# Altium schematic sheet LoRa transceiver_NOswitch.SchDoc("LoRa transceiver_NOswitch")
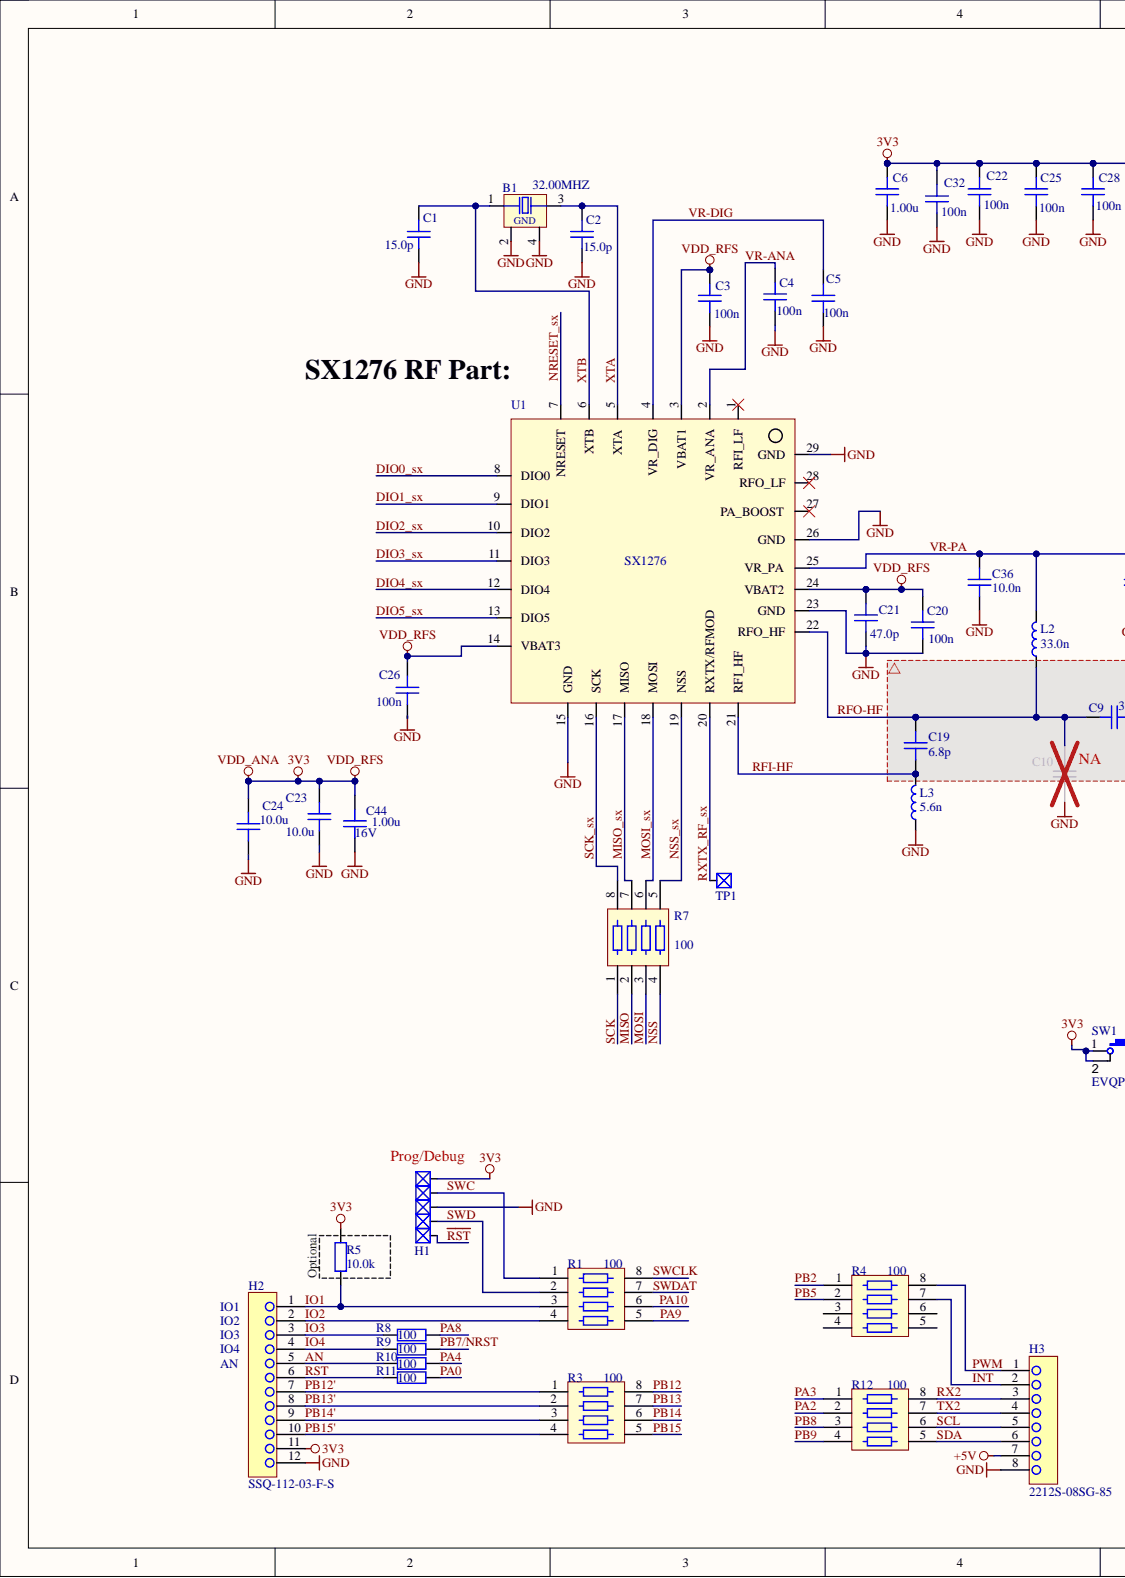
[diagram p0: LoRa transceiver_NOswitch.SchDoc, left]
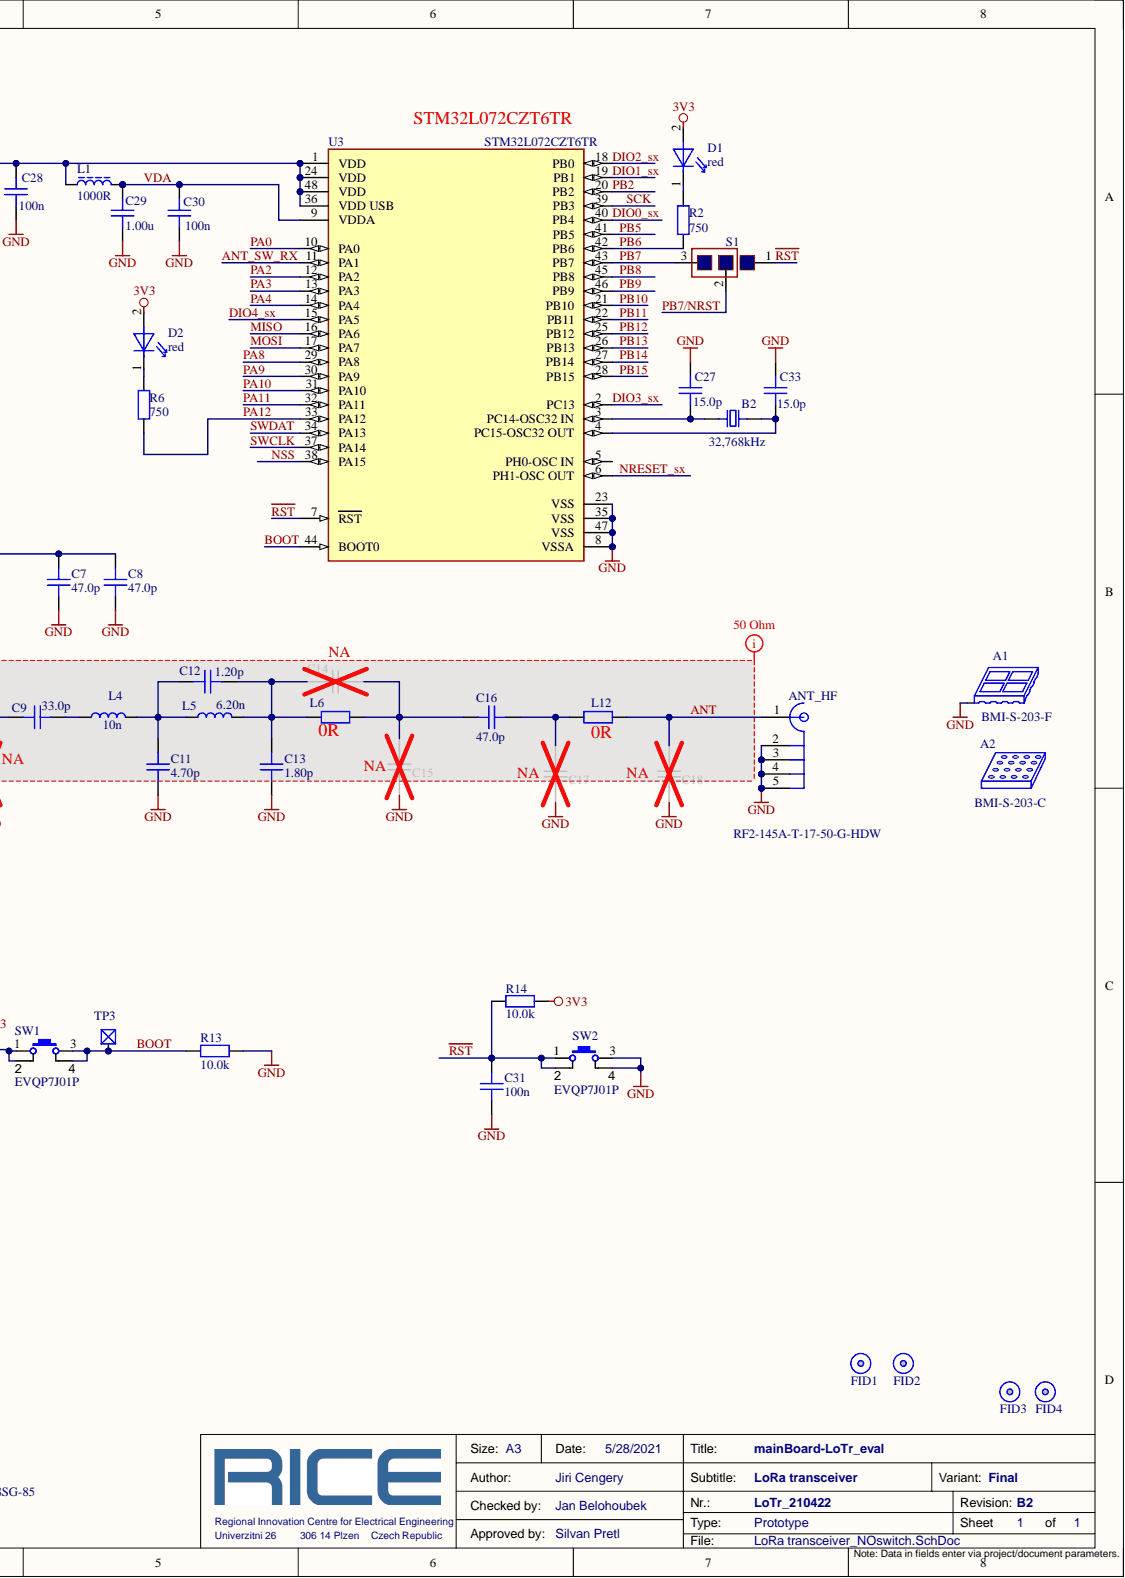
[diagram p1: LoRa transceiver_NOswitch.SchDoc, right]

## Parts
A1  BMI-S-203-F  [sm_mch_shield_W261M_L261M_H51M]
A2  BMI-S-203-C  [sm_mch_ShieldCover_W267M_L267M_H20M]
ANT_HF  RF2-145A-T-17-50-G-HDW  [SM_CON_SMA_F_EDGE_RF2145AT1750G]
B1  32.00MHZ  [sm_osc_402]
B2  32,768kHz  [sm_osc_ABS07]
C1  15.0p  [sm_cap_0402]
C2  15.0p  [sm_cap_0402]
C3  100n  [sm_cap_0402]
C4  100n  [sm_cap_0402]
C5  100n  [sm_cap_0402]
C6  1.00u  [sm_cap_0603]
C7  47.0p  [sm_cap_0402]
C8  47.0p  [sm_cap_0402]
C9  33.0p  [sm_cap_0402]
C10  1.5p  [sm_cap_0402]  (value not drawn)
C11  4.70p  [sm_cap_0402]
C12  1.20p  [sm_cap_0402]
C13  1.80p  [sm_cap_0402]
C14  4.70p  [sm_cap_0402]  (value not drawn)
C15  1.80p  [sm_cap_0402]  (value not drawn)
C16  47.0p  [sm_cap_0402]
C17  47.0p  [sm_cap_0402]  (value not drawn)
C18  47.0p  [sm_cap_0402]  (value not drawn)
C19  6.8p  [sm_cap_0402]
C20  100n  [sm_cap_0402]
C21  47.0p  [sm_cap_0402]
C22  100n  [sm_cap_0402]
C23  10.0u  [sm_cap_0603]
C24  10.0u  [sm_cap_0603]
C25  100n  [sm_cap_0402]
C26  100n  [sm_cap_0402]
C27  15.0p  [sm_cap_0402]
C28  100n  [sm_cap_0402]
C29  1.00u  [sm_cap_0603]
C30  100n  [sm_cap_0402]
C31  100n  [sm_cap_0402]
C32  100n  [sm_cap_0402]
C33  15.0p  [sm_cap_0402]
C36  10.0n  [sm_cap_0402]
C44  1.00u  Voltage: 16V  [sm_cap_0603]
D1  Color: red  [sm_opt_led0603_h37_opp]  (hidden comment: KPTD-1608LVSECK-J3-PF)
D2  Color: red  [sm_opt_led0603_h37_opp]  (hidden comment: KPTD-1608LVSECK-J3-PF)
FID1  Fiducial  [SM_mch_FIDUCIAL_32]  (value not drawn)
FID2  Fiducial  [SM_mch_FIDUCIAL_32]  (value not drawn)
FID3  Fiducial  [SM_mch_FIDUCIAL_32]  (value not drawn)
FID4  Fiducial  [SM_mch_FIDUCIAL_32]  (value not drawn)
H1  TP40_5_pit50  [sm_mch_5pin_pit50]  (value not drawn)  (no BOM)
H2  SSQ-112-03-F-S  [TH_CON_REC1x12_PIT100_L400]
H3  2212S-08SG-85  [TH_CON_REC1x8_PIT100]
L1  1000R  [sm_emi_0603]
L2  33.0n  [sm_ind_0402_ LQW15AN]
L3  5.6n  [sm_ind_0402]
L4  10n  [sm_ind_0402]
L5  6.20n  [sm_ind_0402]
L6  0.00  [sm_res_0402]  (value not drawn)
L6
L12  0.00  [sm_res_0402]  (value not drawn)
L12
R1  100  [sm_res_0804_ARRAY4]
R2  750  [sm_res_0603]
R3  100  [sm_res_0804_ARRAY4]
R4  100  [sm_res_0804_ARRAY4]
R5  10.0k  [sm_res_0603]
R6  750  [sm_res_0603]
R7  100  [sm_res_0804_ARRAY4]
R8  100  [sm_res_0603]
R9  100  [sm_res_0603]
R10  100  [sm_res_0603]
R11  100  [sm_res_0603]
R12  100  [sm_res_0804_ARRAY4]
R13  10.0k  [sm_res_0603]
R14  10.0k  [sm_res_0603]
S1  SMD jumper  [SM_SOLDER_JUMPER_3PIN]  (value not drawn)  (no BOM)
SW1  EVQP7J01P  [SM_SWI_EVQP7J]
SW2  EVQP7J01P  [SM_SWI_EVQP7J]
TP1  TP40  [sm_mch_test40]  (value not drawn)  (no BOM)
TP3  TP40  [sm_mch_test40]  (value not drawn)  (no BOM)
U1  SX1276  [SM_IO_QFN28N_pit26_w236]
U3  STM32L072CZT6TR  [FP-LQFP48-5B-MFG]

## Nets
3V3: C3.2 C6.2 C20.2 C21.2 C22.2 C23.2 C24.2 C25.2 C26.2 C28.2 C32.2 C44.2 D1.2 D2.2 H1.1 H2.11 L1.1 R5.1 R14.1 SW1.1 SW1.2 U1.3(VBAT1) U1.14(VBAT3) U1.24(VBAT2) U3.1(VDD) U3.24(VDD) U3.36(VDD_USB) U3.48(VDD)
+5V: H3.7
GND: A1.1 ANT_HF.2 ANT_HF.3 ANT_HF.4 ANT_HF.5 B1.2 B1.4 C1.1 C2.2 C3.1 C4.1 C5.1 C6.1 C7.1 C8.1 C10.1 C11.1 C13.1 C15.1 C17.1 C18.1 C20.1 C21.1 C22.1 C23.1 C24.1 C25.1 C26.1 C27.1 C28.1 C29.1 C30.1 C31.1 C32.1 C33.1 C36.1 C44.1 H1.3 H2.12 H3.8 L3.1 R13.1 SW2.3 SW2.4 U1.15(GND) U1.23(GND) U1.26(GND) U1.29(GND) U3.8(VSSA) U3.23(VSS) U3.35(VSS) U3.47(VSS)
~{RST}: C31.2 H1.5 R14.2 S1.1 SW2.1 SW2.2 U3.7(RST)
AN: H2.5 R10.2
ANT: ANT_HF.1 C18.2 L12.2
ANT_SW_RX: U3.11(PA1)
BOOT: R13.2 SW1.3 SW1.4 TP3.1 U3.44(BOOT0)
DIO0_sx: U1.8(DIO0) U3.40(PB4)
DIO1_sx: U1.9(DIO1) U3.19(PB1)
DIO2_sx: U1.10(DIO2) U3.18(PB0)
DIO3_sx: U1.11(DIO3) U3.2(PC13)
DIO4_sx: U1.12(DIO4) U3.15(PA5)
DIO5_sx: U1.13(DIO5)
INT: H3.2 R4.7
IO1: H2.1 R1.3 R5.2
IO2: H2.2 R1.4
IO3: H2.3 R8.2
IO4: H2.4 R9.2
MISO: R7.2 U3.16(PA6)
MISO_sx: R7.7 U1.17(MISO)
MOSI: R7.3 U3.17(PA7)
MOSI_sx: R7.6 U1.18(MOSI)
NRESET_sx: U1.7(NRESET) U3.6(PH1-OSC_OUT)
NSS: R7.4 U3.38(PA15)
NSS_sx: R7.5 U1.19(NSS)
PA0: R11.1 U3.10(PA0)
PA2: R12.2 U3.12(PA2)
PA3: R12.1 U3.13(PA3)
PA4: R10.1 U3.14(PA4)
PA8: R8.1 U3.29(PA8)
PA9: R1.5 U3.30(PA9)
PA10: R1.6 U3.31(PA10)
PA11: U3.32(PA11)
PA12: R6.1 U3.33(PA12)
PB2: R4.1 U3.20(PB2)
PB5: R4.2 U3.41(PB5)
PB6: R2.1 U3.42(PB6)
PB7: S1.3 U3.43(PB7)
PB7/NRST: R9.1 S1.2
PB8: R12.3 U3.45(PB8)
PB9: R12.4 U3.46(PB9)
PB10: U3.21(PB10)
PB11: U3.22(PB11)
PB12: R3.8 U3.25(PB12)
PB12': H2.7 R3.1
PB13: R3.7 U3.26(PB13)
PB13': H2.8 R3.2
PB14: R3.6 U3.27(PB14)
PB14': H2.9 R3.3
PB15: R3.5 U3.28(PB15)
PB15': H2.10 R3.4
PWM: H3.1 R4.8
RFI-HF: C19.2 L3.2 U1.21(RFI_HF)
RFO-HF: C9.2 C10.2 C19.1 L2.1 U1.22(RFO_HF)
RST: H2.6 R11.2
RX2: H3.3 R12.8
RXTX_RF_sx: TP1.1 U1.20(RXTX/RFMOD)
SCK: R7.1 U3.39(PB3)
SCK_sx: R7.8 U1.16(SCK)
SCL: H3.5 R12.6
SDA: H3.6 R12.5
SWC: H1.2 R1.1
SWCLK: R1.8 U3.37(PA14)
SWD: H1.4 R1.2
SWDAT: R1.7 U3.34(PA13)
TX2: H3.4 R12.7
VDA: C29.2 C30.2 L1.2 U3.9(VDDA)
VR-ANA: C4.2 U1.2(VR_ANA)
VR-DIG: C5.2 U1.4(VR_DIG)
VR-PA: C7.2 C8.2 C36.2 L2.2 U1.25(VR_PA)
XTA: B1.3 C2.1 U1.5(XTA)
XTB: B1.1 C1.2 U1.6(XTB)
NetB2_1: B2.1 C27.2 U3.3(PC14-OSC32_IN)
NetB2_2: B2.2 C33.2 U3.4(PC15-OSC32_OUT)
NetC9_1: C9.1 L4.1
NetC11_2: C11.2 C12.2 L4.2 L5.1
NetC12_1: C12.1 C13.2 C14.2 L5.2 L6.1
NetC14_1: C14.1 C15.2 C16.2 L6.2
NetC16_1: C16.1 C17.2 L12.1
NetD1_1: D1.1 R2.2
NetD2_1: D2.1 R6.2

Not connected: R4 3 4 5 6; U1 1(RFI_LF) 27(PA_BOOST) 28(RFO_LF); U3 5(PH0-OSC_IN)
